# Altium schematic sheet SME_Main.SchDoc
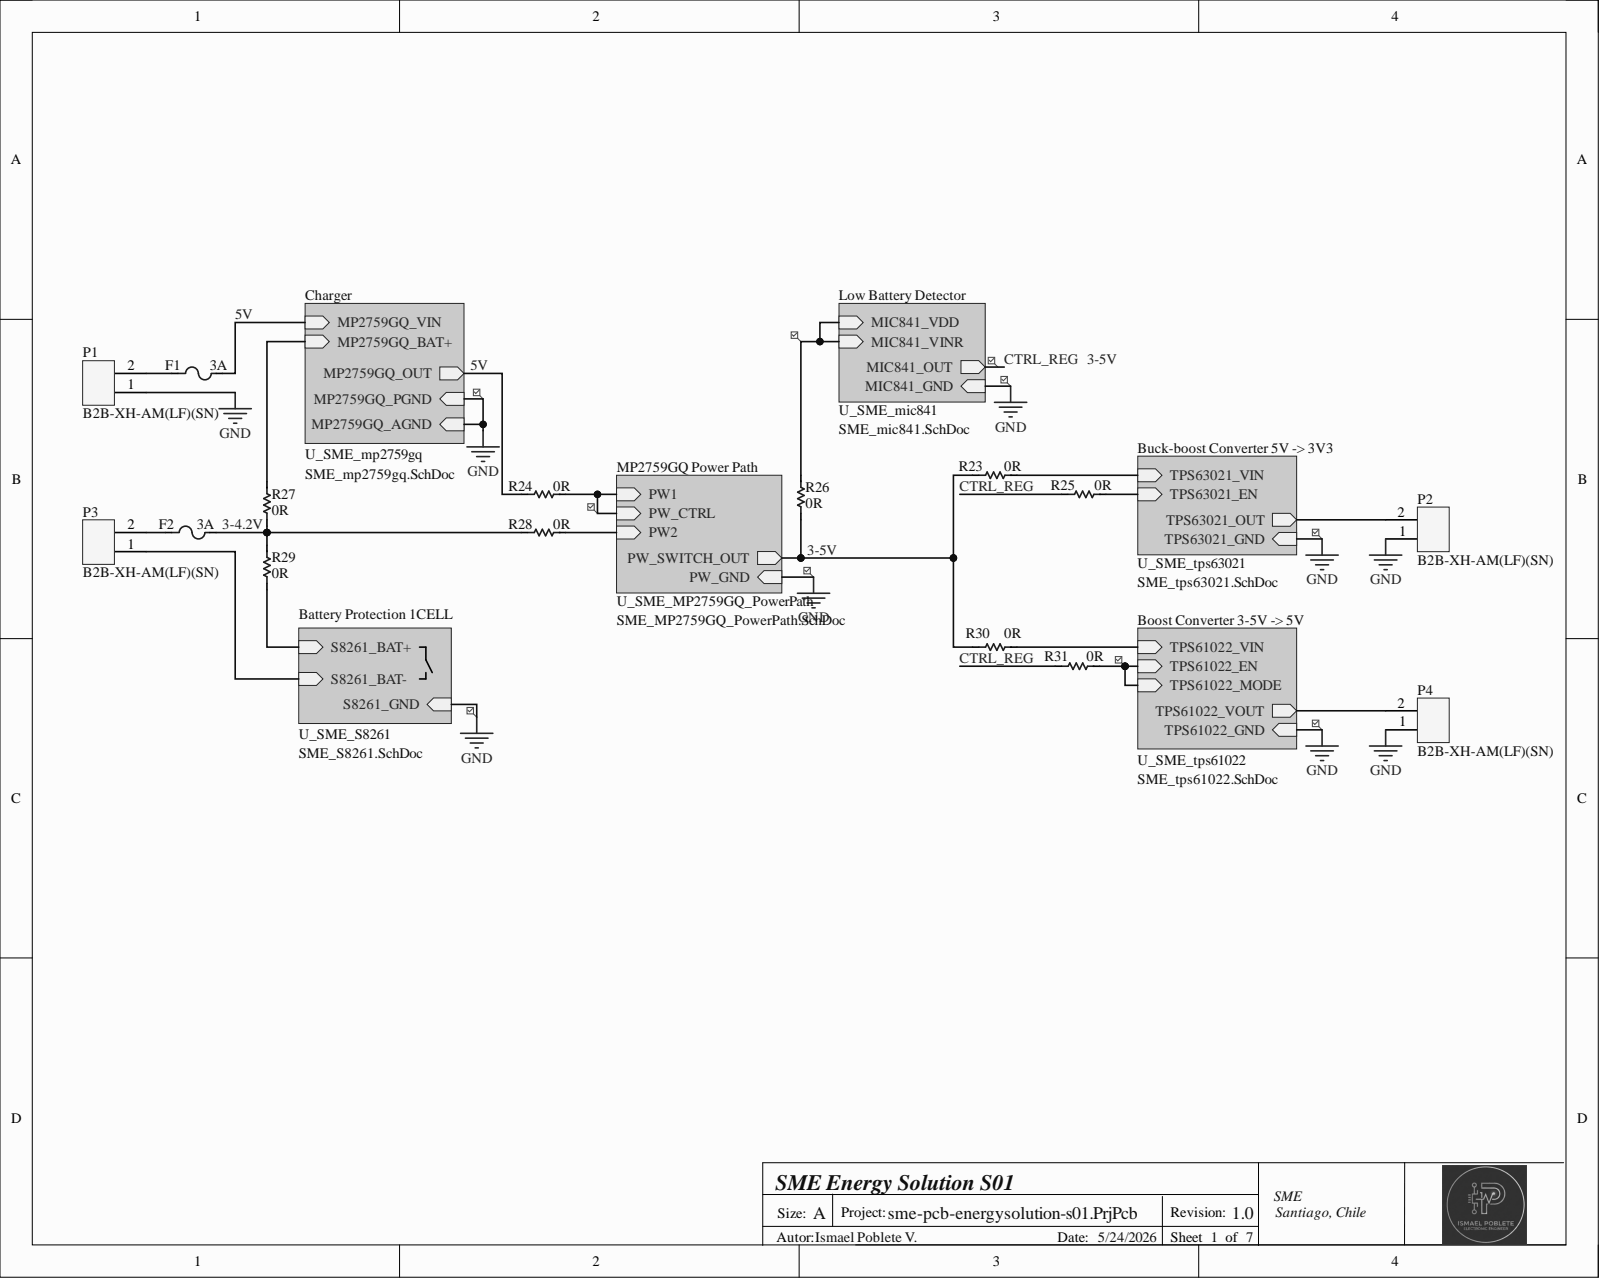
[diagram p0: SME_Main.SchDoc]

## Parts
F1  Fuse value: 3A  [Fuse 22.7mm - 2 Hole]  (hidden comment: FUSE - Littelfuse)
F2  Fuse value: 3A  [Fuse 22.7mm - 2 Hole]  (hidden comment: FUSE - Littelfuse)
P1  B2B-XH-AM(LF)(SN)  [2Pin JST XH-2.54mm]
P2  B2B-XH-AM(LF)(SN)  [2Pin JST XH-2.54mm]
P3  B2B-XH-AM(LF)(SN)  [2Pin JST XH-2.54mm]
P4  B2B-XH-AM(LF)(SN)  [2Pin JST XH-2.54mm]
R23  Resistance: 0R  [RES_1206]  (hidden comment: RC1206FR-070RL)
R24  Resistance: 0R  [RES_1206]  (hidden comment: RC1206FR-070RL)
R25  Resistance: 0R  [RES_1206]  (hidden comment: RC1206FR-070RL)
R26  Resistance: 0R  [RES_1206]  (hidden comment: RC1206FR-070RL)
R27  Resistance: 0R  [RES_1206]  (hidden comment: RC1206FR-070RL)
R28  Resistance: 0R  [RES_1206]  (hidden comment: RC1206FR-070RL)
R29  Resistance: 0R  [RES_1206]  (hidden comment: RC1206FR-070RL)
R30  Resistance: 0R  [RES_1206]  (hidden comment: RC1206FR-070RL)
R31  Resistance: 0R  [RES_1206]  (hidden comment: RC1206FR-070RL)

## Nets
GND: P1.1 P2.1 P4.1
CTRL_REG: R25.1 R31.1
NetF1_1: F1.1 P1.2
NetF1_2: F1.2
NetF2_1: F2.1 P3.2
NetF2_2: F2.2 R27.1 R28.1 R29.2
NetP2_2: P2.2
NetP3_1: P3.1
NetP4_2: P4.2
NetR23_1: R23.1 R26.1 R30.1
NetR23_2: R23.2
NetR24_1: R24.1
NetR24_2: R24.2
NetR25_2: R25.2
NetR26_2: R26.2
NetR27_2: R27.2
NetR28_2: R28.2
NetR29_1: R29.1
NetR30_2: R30.2
NetR31_2: R31.2

## Library data of the parts
F1 F2 = FUSE - Littelfuse: LITTELFUSE WICKMANN - 64600001003 - FUSE HOLDER, 5 X 20MM, PCB MOUNT; Body Material: Polyamide; Color: Black; Current Rating: 6.3A; Illumination Type: Illuminated; Material: Tin; Number of Circuits: 1; Type: thru-hole; Voltage: 250V; Category: Fuse Holders; Component Kind: Standard; ComponentLink1Description: https://datasheet.ciiva.com/14119/gqlittelfuse-sicherungshalter-646-en-14119833.pdf?src-supplier=Distrelec; ComponentLink1URL: https://datasheet.ciiva.com/14119/gqlittelfuse-sicherungshalter-646-en-14119833.pdf?src-supplier=Distrelec; ComponentLink2Description: https://datasheet.ciiva.com/25620/0900766b81196eda-25620105.pdf?src-supplier=RSComponents; ComponentLink2URL: https://datasheet.ciiva.com/25620/0900766b81196eda-25620105.pdf?src-supplier=RSComponents; ComponentLink3Description: https://datasheet.ciiva.com/23593/43388-23593468.pdf?src-supplier=Newark; ComponentLink3URL: https://datasheet.ciiva.com/23593/43388-23593468.pdf?src-supplier=Newark; ComponentLink4Description: https://datasheet.ciiva.com/1270/1480080-1270114.pdf?src-supplier=Element14; ComponentLink4URL: https://datasheet.ciiva.com/1270/1480080-1270114.pdf?src-supplier=Element14; ComponentLink5Description: https://datasheet.ciiva.com/4480/646-648-640-lf-050901-4480295.pdf?src-supplier=Mouser; ComponentLink5URL: https://datasheet.ciiva.com/4480/646-648-640-lf-050901-4480295.pdf?src-supplier=Mouser; ComponentLink6Description: https://datasheet.ciiva.com/7660/646-648-640-lf-050901-7660727.pdf?src-supplier=Element14; ComponentLink6URL: https://datasheet.ciiva.com/7660/646-648-640-lf-050901-7660727.pdf?src-supplier=Element14; ComponentLink7Description: https://datasheet.ciiva.com/295/646-648-640-lf-050901-295754.pdf?src-supplier=Digi-Key; ComponentLink7URL: https://datasheet.ciiva.com/295/646-648-640-lf-050901-295754.pdf?src-supplier=Digi-Key; ComponentLink8Description: https://datasheet.ciiva.com/15187/getdatasheetpartid-57320-15187869.pdf?src-supplier=Verical; ComponentLink8URL: https://datasheet.ciiva.com/15187/getdatasheetpartid-57320-15187869.pdf?src-supplier=Verical; Depth: 5.5mm; Flammability Rating: UL94 V-1; Fuse Current: 6.3A; Lead Free: Lead Free; Length: 25.8mm; Manufacturer: Littelfuse Wickmann; Manufacturer Part Number: 64600001003; Max Operating Temperature: 70°C; Min Operating Temperature: -25°C; Mount: Horizontal,PCB; Number of Pins: 2; Number of Poles: 1; Package: 2 Pos; Package Quantity: 1000; Packaging: Bulk; Pricing 1: 1=0.84000, 5=0.81200, 10=0.76000, 50=0.62880, 100=0.52400, 250=0.51092, 500=0.43230, 1000=0.37990, 5000=0.34060 (USD); REACH SVHC: Unknown; RoHS: Yes; Stock 1: 1999; Supplier 1: Digi-Key; Supplier Part Number 1: WK6244-ND; Termination: PC Pin; Voltage Rating: 250V; Voltage Rating (AC): 250V; Voltage Rating (DC): 250V; Width: 12mm; Library Name: SME.IntLib
P1-P4 = B2B-XH-AM(LF)(SN): CONN HEADER VERT 2POS 2.5MM; Component Kind: Standard; Library Name: SME.IntLib
R23-R31 = RC1206FR-070RL: Thick Film Resistors - SMD 0 Ohms 250 mW 1206 1%; Manufacturer: Yageo Group; Geometry.Height: 0.65mm; Case/Package: 1206; Component Kind: Standard; Datasheet Link: https://www.yageogroup.com/content/datasheet/asset/file/PYU-RC_GROUP_51_ROHS_L; Manufacturer Part Number: RC1206FR-070RL; Manufacturer_Name: Yageo Group; Manufacturer_Part_Number: RC1206FR-070RL; Mouser Part Number: 603-RC1206FR-070RL; Mouser Price/Stock: https://www.mouser.co.uk/ProductDetail/YAGEO/RC1206FR-070RL?qs=%2FbpERZPLKKvgeF8VBtNQLg%3D%3D; Package: 1206; Type: SMD; Library Name: SME.IntLib
